# Altium schematic sheet Main Power Input.SchDoc
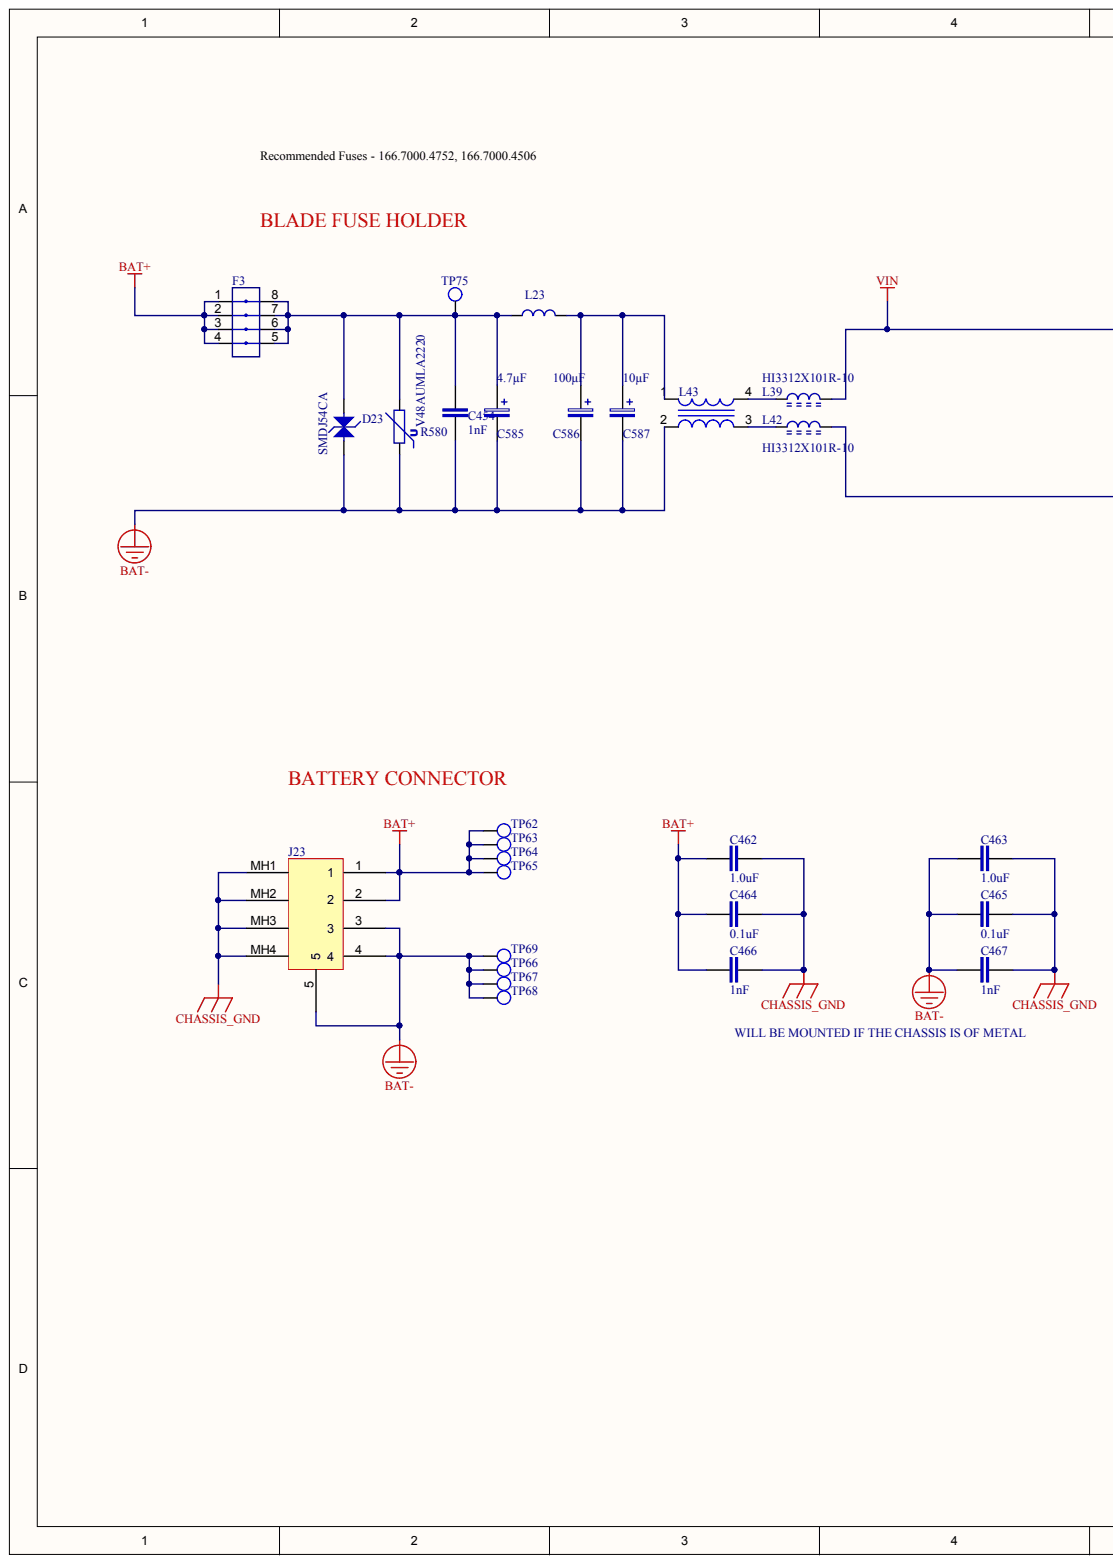
[diagram p0: Main Power Input.SchDoc, left]
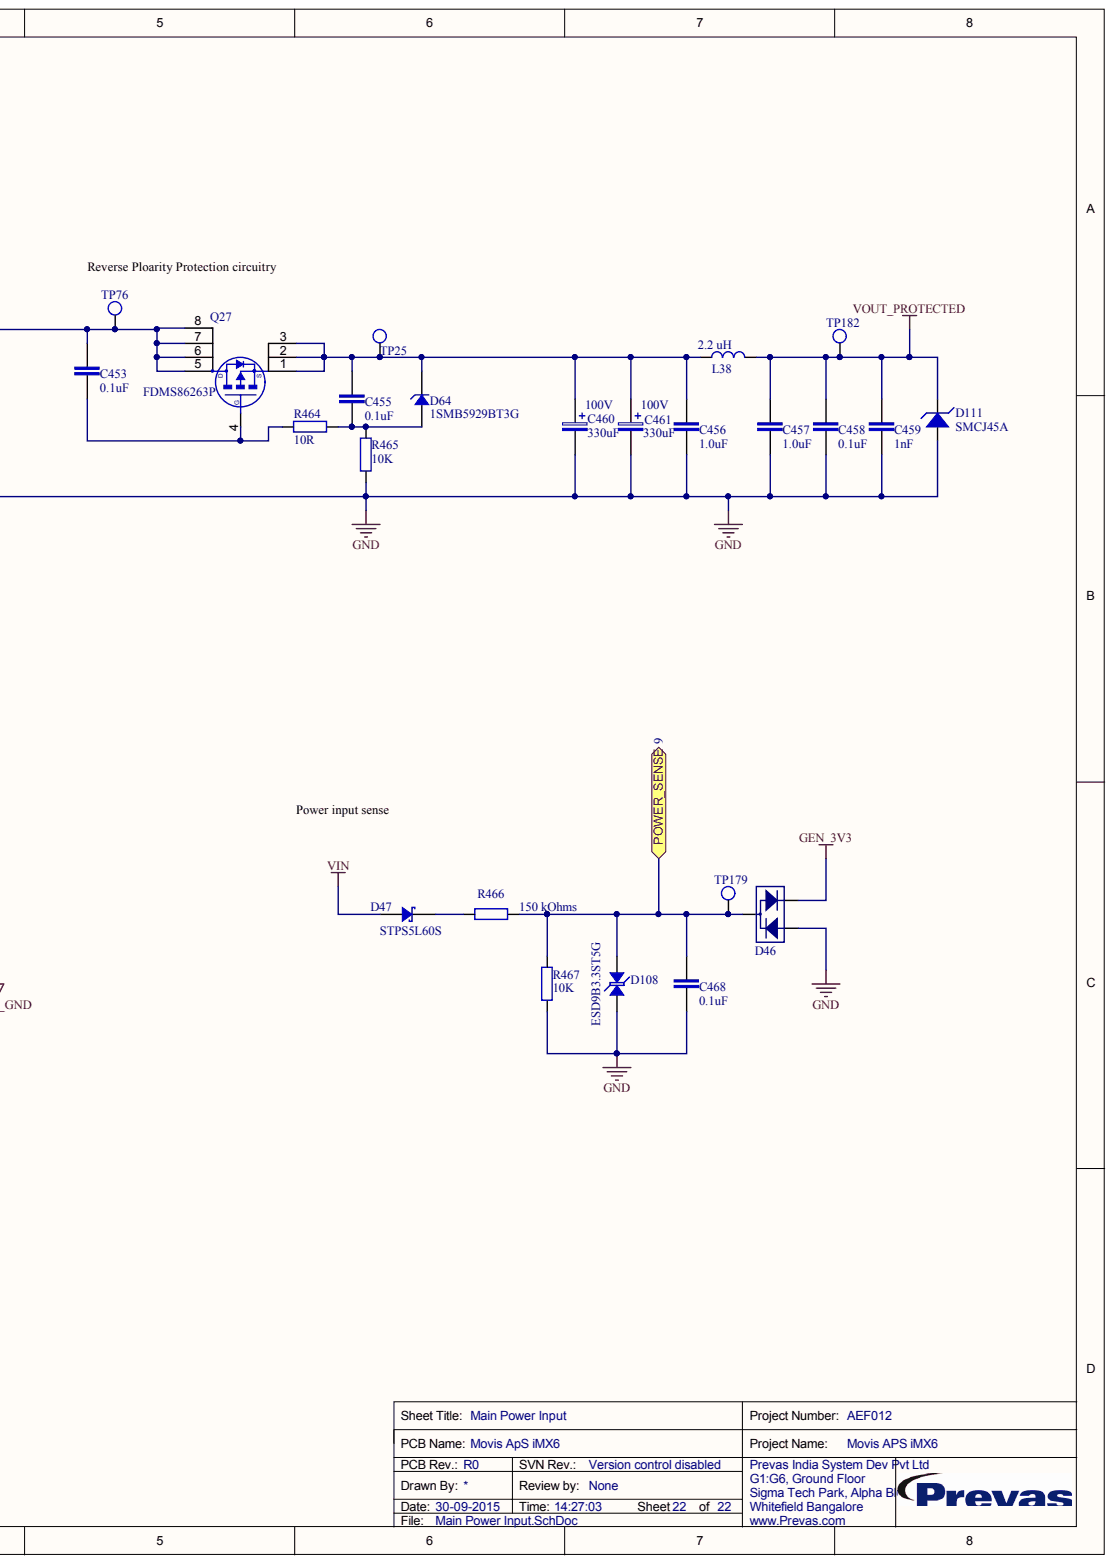
[diagram p1: Main Power Input.SchDoc, right]

## Parts
C453  0.1uF  [CAPC2012X145N]
C454  1nF  [CAPC1608X87N]
C455  0.1uF  [CAPC2012X145N]
C456  1.0uF  elec_voltage_rating: 100V  [CAPC2012X145N]
C457  1.0uF  [CAPC2012X145N]
C458  0.1uF  [CAPC2012X145N]
C459  1nF  [CAPC1608X87N]
C460  330uF  elec_voltage_rating: 100V  [CAPAE1900X1650N]
C461  330uF  elec_voltage_rating: 100V  [CAPAE1900X1650N]
C462  1.0uF  [CAPC2012X145N]
C463  1.0uF  [CAPC2012X145N]
C464  0.1uF  [CAPC2012X145N]
C465  0.1uF  [CAPC2012X145N]
C466  1nF  [CAPC1608X87N]
C467  1nF  [CAPC1608X87N]
C468  0.1uF  [CAPC2012X145N]
C585  4.7µF  [CAPAE8984X103N]
C586  100µF  [CAPAE210191X220N]
C587  10µF  [CAPAE109104X103N]
D23  SMDJ54CA  [DIOM7959X262N]
D46  BAV99  [SOT95P240X110-3N]  (value not drawn)
D47  STPS5L60S  [DIOM7959X265N]
D64  1SMB5929BT3G  [DIOM5436X241N]
D108  ESD9B3.3ST5G  [SODFL1006X43N]
D111  SMCJ45A  [DIOM7959X262N]
F3  178.6165.0001  [FUSE_HOLDER]  (value not drawn)
J23  99-3732-202-04_sch  [CON4RA_M12D]  (value not drawn)
L23  IND  [INDP6872X30N]  (value not drawn)
L38  2.2 uH  [INDC7875X50N]
L39  HI3312X101R-10  [INDC8634X25N]
L42  HI3312X101R-10  [INDC8634X25N]
L43  CM2545X171R-10  [IND_254P1410X1016-4N]  (value not drawn)
Q27  FDMS86263P  [SON127P608X500X110-8N]
R464  10R  [RESC1608X55N]
R465  10K  [RESC1608X55N]
R466  150 kOhms  [RESC3216X60N]
R467  10K  [RESC1608X55N]
R580  elec_value: V48AUMLA2220  [RESC5750X300N]  (hidden comment: RES_VDR)
TP25  Testpoint  [TP_SMD]  (value not drawn)  (no BOM)
TP62  Testpoint  [TP_SMD]  (value not drawn)  (no BOM)
TP63  Testpoint  [TP_SMD]  (value not drawn)  (no BOM)
TP64  Testpoint  [TP_SMD]  (value not drawn)  (no BOM)
TP65  Testpoint  [TP_SMD]  (value not drawn)  (no BOM)
TP66  Testpoint  [TP_SMD]  (value not drawn)  (no BOM)
TP67  Testpoint  [TP_SMD]  (value not drawn)  (no BOM)
TP68  Testpoint  [TP_SMD]  (value not drawn)  (no BOM)
TP69  Testpoint  [TP_SMD]  (value not drawn)  (no BOM)
TP75  Testpoint  [TP_SMD]  (value not drawn)  (no BOM)
TP76  Testpoint  [TP_SMD]  (value not drawn)  (no BOM)
TP179  Testpoint  [TP_SMD]  (value not drawn)  (no BOM)
TP182  Testpoint  [TP_SMD]  (value not drawn)  (no BOM)

## Ports
POWER_SENSE

## Nets
BAT+: C462.2 C464.2 C466.2 F3.1 F3.2 F3.3 F3.4 J23.1 J23.2 TP62.1 TP63.1 TP64.1 TP65.1
BAT-: C454.1 C463.2 C465.2 C467.2 C585.2 C586.2 C587.2 D23.A J23.3 J23.4 J23.5 L43.2 R580.1 TP66.1 TP67.1 TP68.1 TP69.1
CHASSIS_GND: C462.1 C463.1 C464.1 C465.1 C466.1 C467.1 J23.MH1 J23.MH2 J23.MH3 J23.MH4
GEN_3V3: D46.2
GND: C456.1 C457.1 C458.1 C459.1 C460.2 C461.2 C468.1 D46.1 D108.1 D111.A L42.2 R465.2 R467.1
VIN: C453.2 D47.A L39.2 Q27.5 Q27.6 Q27.7 Q27.8 TP76.1
VOUT_PROTECTED: C457.2 C458.2 C459.2 D111.C L38.2 TP182.1
POWER_SENSE: C468.2 D46.3 D108.2 R466.2 R467.2 TP179.1 ; port POWER_SENSE
NetC453_1: C453.1 Q27.4(G) R464.2
NetC454_2: C454.2 C585.1 D23.C F3.5 F3.6 F3.7 F3.8 L23.1 R580.2 TP75.1
NetC455_1: C455.1 D64.A R464.1 R465.1
NetC455_2: C455.2 C456.2 C460.1 C461.1 D64.C L38.1 Q27.1 Q27.2 Q27.3 TP25.1
NetC586_1: C586.1 C587.1 L23.2 L43.1
NetD47_C: D47.C R466.1
NetL39_1: L39.1 L43.4
NetL42_1: L42.1 L43.3

## Library data of the parts
C453 C455 C458 C464 C465 C468 = INNISI-99: CAP CER 0.1UF 100V 10% X7R 0805; ref_part_type: /capacitor/non_polarized/ceramic/; source_manufacturer_1: TDK Corporation; source_manufacturer_1_pn: C2012X7R2A104K125AA; cae_altium_pcb_status: Prototype; Database Table Name: altium_default; db_rev: 7645; elec_temp_range: -55°C ~ 125°C; phy_height: 1.45mm; phy_mounting: SMD; phy_packaging_type: 0805; ref_datasheet: INNISI-87; ref_keyword: Ceramic Capacitor; cae_altium_sch_status: Prototype; Component Kind: Standard; ComponentLink1Description: Datasheet; ComponentLink1URL: https://cop201.prevas.dk/viewvc/datasheet/INNISI-87.pdf?root=hw_components&view=co; db_created: 2015-08-03 11:06:47.0; db_last_updated: 03-08-2015 11:06:49; db_local_id: INNISI-99; db_status: Prototype; elec_tolerance: 10%; elec_value: 0.1uF; elec_voltage_rating: 100V; phy_material: X7R; Library Name: altium_mysql.dblib
C454 C459 C466 C467 = INNISI-101: Ceramic capacitor C0G 0603; ref_part_type: /capacitor/non_polarized/ceramic/; source_manufacturer_1: KEMET; source_manufacturer_1_pn: C0603C102J2GACAUTO; cae_altium_pcb_status: Prototype; Database Table Name: altium_default; db_rev: 7662; elec_temp_range: -55 to 125; elec_tolerance: 5%; elec_voltage_rating: 200V; phy_height: 0.87mm; phy_material: NPO; phy_mounting: SMD; phy_packaging_type: 0603; ref_datasheet: INNISI-88; ref_keyword: Multilayer chip capacitor; cae_altium_sch_status: Prototype; Component Kind: Standard; ComponentLink1Description: Datasheet; ComponentLink1URL: https://cop201.prevas.dk/viewvc/datasheet/INNISI-88.pdf?root=hw_components&view=co; db_created: 2015-08-04 09:54:42.0; db_last_updated: 04-08-2015 09:54:43; db_local_id: INNISI-101; db_status: Prototype; elec_value: 1nF; Library Name: altium_mysql.dblib
C456 C457 C462 C463 = INNISI-100: CAP CER 1UF 100V 10% X7S 0805; ref_part_type: /capacitor/non_polarized/ceramic/; source_manufacturer_1: TDK Corporation; source_manufacturer_1_pn: C2012X7S2A105K125AB; cae_altium_pcb_status: Prototype; Database Table Name: altium_default; db_rev: 7646; elec_temp_range: -55°C ~ 125°C; phy_height: 1.45mm; phy_mounting: SMD; phy_packaging_type: 0805; ref_datasheet: INNISI-87; ref_keyword: Ceramic Capacitor; cae_altium_sch_status: Prototype; Component Kind: Standard; ComponentLink1Description: Datasheet; ComponentLink1URL: https://cop201.prevas.dk/viewvc/datasheet/INNISI-87.pdf?root=hw_components&view=co; db_created: 2015-08-03 11:08:52.0; db_last_updated: 03-08-2015 11:08:54; db_local_id: INNISI-100; db_status: Prototype; elec_tolerance: 10%; elec_value: 1.0uF; phy_material: X7S; Library Name: altium_mysql.dblib
  C457 C462 C463: elec_voltage_rating: 100V
C460 C461 = INRAAN-91: Aluminum electrolytic capacitor; ref_part_type: /capacitor/polarized/al/; source_manufacturer_1: Panasonic; source_manufacturer_1_pn: EEV-FK2A331M; cae_altium_pcb_status: Prototype; Database Table Name: altium_default; db_rev: 6700; elec_frequency_rating: 120Hz; elec_temp_range: -55 C to 105 C; elec_tolerance: 20 %; phy_height: 17mm; phy_mounting: SMD; ref_datasheet: INRAAN-93; cae_altium_sch_status: Prototype; Component Kind: Standard; ComponentLink1Description: Datasheet; ComponentLink1URL: https://cop201.prevas.dk/viewvc/datasheet/INRAAN-93.pdf?root=hw_components&view=co; db_created: 2014-02-10 09:01:09.0; db_last_updated: 9/1/2014 4:27:05 AM; db_local_id: INRAAN-91; db_status: Prototype; elec_value: 330uF; ref_keyword: Aluminum electrolytic capacitor; Library Name: altium_mysql.dblib
C585 = INNISI-71: CAP ALUM 4.7UF 20% 200V SMD; ref_part_type: /capacitor/polarized/al/; source_manufacturer_1: Nichicon; source_manufacturer_1_pn: UUB2D4R7MNL1GS; cae_altium_pcb_status: Prototype; Database Table Name: altium_default; db_rev: 7509; elec_temp_range: -40°C ~ 125°C; phy_height: 10.50mm; ref_datasheet: INNISI-69; ref_keyword: Aluminum Capacitors; cae_altium_sch_status: Prototype; Component Kind: Standard; ComponentLink1Description: Datasheet; ComponentLink1URL: https://cop201.prevas.dk/viewvc/datasheet/INNISI-69.pdf?root=hw_components&view=co; db_created: 2015-07-08 05:07:25.0; db_last_updated: 08-07-2015 05:07:27; db_local_id: INNISI-71; db_status: Prototype; elec_tolerance: ±20%; elec_value: 4.7µF; elec_voltage_rating: 200V; phy_mounting: SMD; Library Name: altium_mysql.dblib
C586 = INNISI-72: CAP ALUM 100UF 20% 200V SMD; ref_part_type: /capacitor/polarized/al/; source_manufacturer_1: Panasonic Electronic Components; source_manufacturer_1_pn: EEV-EB2D101M; cae_altium_pcb_status: Prototype; Database Table Name: altium_default; db_rev: 7510; elec_temp_range: -25°C ~ 105°C; phy_height: 21.50mm; ref_datasheet: INNISI-70; ref_keyword: Aluminum Capacitors; cae_altium_sch_status: Prototype; Component Kind: Standard; ComponentLink1Description: Datasheet; ComponentLink1URL: https://cop201.prevas.dk/viewvc/datasheet/INNISI-70.pdf?root=hw_components&view=co; db_created: 2015-07-08 05:14:06.0; db_last_updated: 08-07-2015 05:14:08; db_local_id: INNISI-72; db_status: Prototype; elec_tolerance: ±20%; elec_value: 100µF; elec_voltage_rating: 200V; phy_mounting: SMD; Library Name: altium_mysql.dblib
C587 = INNISI-70: CAP ALUM 10UF 20% 200V SMD; ref_part_type: /capacitor/polarized/al/; source_manufacturer_1: Nichicon; source_manufacturer_1_pn: UUB2D100MNL1GS; cae_altium_pcb_status: Prototype; Database Table Name: altium_default; db_rev: 7508; elec_temp_range: -40°C ~ 125°C; ref_datasheet: INNISI-69; ref_keyword: Alumininum Capacitors; cae_altium_sch_status: Prototype; Component Kind: Standard; ComponentLink1Description: Datasheet; ComponentLink1URL: https://cop201.prevas.dk/viewvc/datasheet/INNISI-69.pdf?root=hw_components&view=co; db_created: 2015-07-08 05:00:18.0; db_last_updated: 08-07-2015 05:00:20; db_local_id: INNISI-70; db_status: Prototype; elec_tolerance: ±20%; elec_value: 10µF; elec_voltage_rating: 200V; phy_mounting: SMD; Library Name: altium_mysql.dblib
D23 = (no symbol name): TVS Diodes - Transient Voltage Suppressors TVS Diode SMC Suf MT; ref_part_type: /diode/transient/; source_manufacturer_1: Littelfuse; cae_altium_pcb_status: Prototype; db_local_id: INRAAN-202; db_rev: 6840; elec_temp_range: -65C to 150C; elec_voltage_rating: 54V; phy_height: 2.62mm; phy_mounting: SMD; phy_packaging_type: DO-214AB; ref_datasheet: INRAAN-187; cae_altium_sch_status: Prototype; Component Kind: Standard; ComponentLink1Description: Datasheet; ComponentLink1URL: https://cop201.prevas.dk/viewvc/datasheet/INRAAN-187.pdf?root=hw_components&view=co; db_created: 2014-09-12 06:34:12.0; db_last_updated: 9/12/2014 6:34:14 AM; db_status: Prototype; elec_value: SMDJ54CA; ref_keyword: TVS Diodes - Transient Voltage Suppressors; source_manufacturer_1_pn: SMDJ54CA; Library Name: Movis-main-card.SCHLIB
D46 = JEPE-21: Diode Silicon Low Leakage SOT-23; ref_part_type: /diode/normal/; source_manufacturer_1: Infineon; cae_altium_pcb_status: Prototype; Database Table Name: altium_default; db_rev: 2162; elec_current_rating: 200mA; elec_power_rating: 330mW; elec_temp_range: -65 to +150; elec_value: BAV199; elec_voltage_rating: 80V; phy_height: 1.0mm; phy_mounting: SMD; ref_datasheet: JEPE-15; ref_keyword: Diode SMD Series Pair Configuration; cae_altium_sch_status: Prototype; Component Kind: Standard; ComponentLink1Description: Datasheet; ComponentLink1URL: https://cop201.prevas.dk/viewvc/datasheet/JEPE-15.pdf?root=hw_components&view=co; db_created: 2008-05-28 13:53:32; db_last_updated: 3/1/2010 1:26:01 PM; db_local_id: JEPE-21; db_status: Prototype; phy_packaging_type: SOT-23; source_manufacturer_1_pn: BAV199; Library Name: altium_mysql.dblib
D47 = NIMA-251: Diode Power Rectifier Case DO-214AB; ref_part_type: /diode/schottky/; source_manufacturer_1: STMicroelectronics; cae_altium_pcb_status: Prototype; Database Table Name: altium_default; db_rev: 4795; elec_current_rating: 5A; elec_temp_range: -65 to 150; elec_voltage_rating: 60V; phy_height: 2.65mm; phy_material: Epoxy; phy_mounting: SMD; phy_packaging_type: SMC; ref_datasheet: NIMA-182; ref_keyword: Schottky Diode SMD; cae_altium_sch_status: Prototype; Component Kind: Standard; ComponentLink1Description: Datasheet; ComponentLink1URL: https://cop201.prevas.dk/viewvc/datasheet/NIMA-182.pdf?root=hw_components&view=co; db_created: 2012-06-27 23:43:13.0; db_last_updated: 6/27/2012 11:43:13 PM; db_local_id: NIMA-251; db_status: Prototype; elec_value: STPS5L60S; source_manufacturer_1_pn: STPS5L60S; Library Name: altium_mysql.dblib
D64 = CHPE-286: Zener Diode 15V 3W; ref_part_type: /diode/zener/; source_manufacturer_1: ON Semiconductor; cae_altium_pcb_status: Prototype; Database Table Name: altium_default; db_rev: 4492; elec_temp_range: -65 to +150; phy_height: 2.41mm; phy_material: Silicon; phy_mounting: SMD; phy_packaging_type: SMB; ref_datasheet: GEPW-102; ref_keyword: Zener Diode SMD; cae_altium_sch_status: Prototype; Component Kind: Standard; ComponentLink1Description: Datasheet; ComponentLink1URL: https://cop201.prevas.dk/viewvc/datasheet/GEPW-102.pdf?root=hw_components&view=co; db_created: 2012-04-02 03:10:43.0; db_last_updated: 4/2/2012 3:10:44 AM; db_local_id: CHPE-286; db_status: Prototype; elec_power_rating: 3W; elec_value: 1SMB5929BT3G; elec_voltage_rating: 15V; source_manufacturer_1_pn: 1SMB5929BT3G; Library Name: altium_mysql.dblib
D108 = INRAAN-22: Micro?Packaged Diodes for ESD Protection; ref_part_type: /diode/schottky/; source_manufacturer_1: ON SEMICONDUCTOR; cae_altium_pcb_status: Prototype; Database Table Name: altium_default; db_rev: 6515; elec_current_rating: 1A; elec_temp_range: -55 TO 150; elec_voltage_rating: 3.3 TO 5V; phy_height: 0.43; phy_mounting: SMD; phy_packaging_type: SOD-923; ref_datasheet: INRAAN-24; cae_altium_sch_status: Prototype; Component Kind: Standard; ComponentLink1Description: Datasheet; ComponentLink1URL: https://cop201.prevas.dk/viewvc/datasheet/INRAAN-24.pdf?root=hw_components&view=co; db_created: 2013-11-18 07:59:40.0; db_last_updated: 4/3/2014 6:43:30 AM; db_local_id: INRAAN-22; db_status: Prototype; elec_value: ESD9B3.3ST5G; ref_keyword: SOD-923; source_manufacturer_1_pn: ESD9B3.3ST5G; Library Name: altium_mysql.dblib
D111 = (no symbol name): TVS Diodes - Transient Voltage Suppressors 45Vr 1500W 20.6A 5% UniDirectional; ref_part_type: /diode/transient/; source_manufacturer_1: Littelfuse; cae_altium_pcb_status: Prototype; db_local_id: INRAAN-201; db_rev: 6839; elec_temp_range: -65C to 150C; elec_voltage_rating: 45V; phy_height: 2.62mm; phy_mounting: SMD; phy_packaging_type: DO-214AB; ref_datasheet: INRAAN-186; cae_altium_sch_status: Prototype; Component Kind: Standard; ComponentLink1Description: Datasheet; ComponentLink1URL: https://cop201.prevas.dk/viewvc/datasheet/INRAAN-186.pdf?root=hw_components&view=co; db_created: 2014-09-12 06:24:26.0; db_last_updated: 9/12/2014 6:30:48 AM; db_status: Prototype; elec_value: SMCJ45A; ref_keyword: TVS Diodes - Transient Voltage Suppressors; source_manufacturer_1_pn: SMCJ45A; Library Name: Movis-main-card.SCHLIB
F3 = INNISI-65: Fuse Holder FUSEHOLDER FOR FLR; ref_part_type: /elmech/fuse/; source_manufacturer_1: Littelfuse; cae_altium_pcb_status: Prototype; Database Table Name: altium_default; db_rev: 7467; elec_voltage_rating: 80 V; phy_mounting: Leaded; ref_datasheet: INNISI-64; cae_altium_sch_status: Prototype; Component Kind: Standard; ComponentLink1Description: Datasheet; ComponentLink1URL: https://cop201.prevas.dk/viewvc/datasheet/INNISI-64.pdf?root=hw_components&view=co; db_created: 2015-06-18 09:52:46.0; db_last_updated: 18-06-2015 09:52:48; db_local_id: INNISI-65; db_status: Prototype; ref_keyword: Fuse Holder; source_manufacturer_1_pn: 178.6165.0001; Library Name: altium_mysql.dblib
J23 = INNISI-48: Female receptacle, 90°, rear-mounted, PCB solder; ref_part_type: /connector/4 pins/; source_manufacturer_1: Binder; cae_altium_pcb_status: Prototype; Database Table Name: altium_default; db_rev: 7471; elec_temp_range: -40 C- +85 C; elec_value: 99 3732 203 04; elec_voltage_rating: 250 V; phy_mounting: Leaded; ref_datasheet: INNISI-60; ref_keyword: Female panel mount 4 pin connector; cae_altium_sch_status: Prototype; Component Kind: Standard; ComponentLink1Description: Datasheet; ComponentLink1URL: https://cop201.prevas.dk/viewvc/datasheet/INNISI-60.pdf?root=hw_components&view=co; db_created: 2015-06-08 10:04:26.0; db_last_updated: 19-06-2015 04:02:02; db_local_id: INNISI-48; db_status: Prototype; source_manufacturer_1_pn: 99 3732 203 04; Library Name: altium_mysql.dblib
L23 = INNISI-69: Fixed Inductors 1.00uH 20%; ref_part_type: /inductor/; source_manufacturer_1: TT Electronics; source_manufacturer_1_pn: HA72E-061R0LFTR13; cae_altium_pcb_status: Prototype; Database Table Name: altium_default; db_rev: 7507; elec_current_rating: 10.3A; elec_frequency_rating: 100KHz; elec_temp_range: - 40 C to + 155 C; elec_value: 1.uH; phy_height: 3 mm; phy_mounting: SMD; ref_datasheet: INNISI-68; ref_keyword: Fixed Inductor 1.00uH; cae_altium_sch_status: Prototype; Component Kind: Standard; ComponentLink1Description: Datasheet; ComponentLink1URL: https://cop201.prevas.dk/viewvc/datasheet/INNISI-68.pdf?root=hw_components&view=co; db_created: 2015-07-08 04:41:35.0; db_last_updated: 08-07-2015 04:41:37; db_local_id: INNISI-69; db_status: Prototype; elec_tolerance: 20%; Library Name: altium_mysql.dblib
L38 = INNISI-25: Fixed Inductors 2.2uH 14A; ref_part_type: /inductor/; source_manufacturer_1: Coiltronics; source_manufacturer_1_pn: HCP0805-2R2-R; cae_altium_pcb_status: Prototype; Database Table Name: altium_default; db_rev: 7358; elec_frequency_rating: 2MHz; elec_temp_range: - 40 C to + 125 C; elec_tolerance: 25 %; phy_height: 5 mm; phy_mounting: SMD; cae_altium_sch_status: Prototype; Component Kind: Standard; ComponentLink1Description: Datasheet; ComponentLink1URL: https://cop201.prevas.dk/viewvc/datasheet/INNISI-25.pdf?root=hw_components&view=co; db_created: 2015-06-02 09:23:47.0; db_last_updated: 02-06-2015 09:23:48; db_local_id: INNISI-25; db_status: Prototype; elec_current_rating: 14 A; elec_value: 2.2 uH; ref_datasheet: INNISI-25; ref_keyword: Fixed Inductors; Library Name: altium_mysql.dblib
L39 L42 = INNISI-26: EMI Filter Beads, Chips & Arrays 100ohms 100MHz 10A Monolithic 3312 SMD; ref_part_type: /inductor/; source_manufacturer_1: Laird Technologies; cae_altium_pcb_status: Prototype; Database Table Name: altium_default; db_rev: 7359; elec_temp_range: - 55 C to + 125 C; phy_height: 2.28mm; phy_packaging_type: 3312 (8531 metric); ref_keyword: EMI Ferrite Chip Beads; cae_altium_sch_status: Prototype; Component Kind: Standard; ComponentLink1Description: Datasheet; ComponentLink1URL: https://cop201.prevas.dk/viewvc/datasheet/INNISI-26.pdf?root=hw_components&view=co; db_created: 2015-06-02 09:35:27.0; db_last_updated: 02-06-2015 09:35:28; db_local_id: INNISI-26; db_status: Prototype; elec_current_rating: 10A; elec_value: HI3312X101R-10; phy_mounting: SMD; ref_datasheet: INNISI-26; source_manufacturer_1_pn: HI3312X101R-10; Library Name: altium_mysql.dblib
L43 = INNISI-51: CHOKE COMMON MODE 170 OHMS SMD; ref_part_type: /filter/choke/; source_manufacturer_1: Laird Technologies; cae_altium_pcb_status: Prototype; Database Table Name: altium_default; db_rev: 7408; elec_current_rating: 10A; elec_temp_range: - 40 C to + 125 C; phy_height: 9.32mm; ref_datasheet: INNISI-49; ref_keyword: Ferrite Chip Beads, Common Mode; cae_altium_sch_status: Prototype; Component Kind: Standard; ComponentLink1Description: Datasheet; ComponentLink1URL: https://cop201.prevas.dk/viewvc/datasheet/INNISI-49.pdf?root=hw_components&view=co; db_created: 2015-06-09 03:50:21.0; db_last_updated: 09-06-2015 03:50:23; db_local_id: INNISI-51; db_status: Prototype; elec_value: CM2545X171R-10; phy_mounting: SMD; source_manufacturer_1_pn: CM2545X171R-10; Library Name: altium_mysql.dblib
Q27 = INRAAN-213: MOSFET PT5 150/25V Pch Pwr Trench MOSFET; ref_part_type: /transistor/mosfet/p_channel/; source_manufacturer_1: Fairchild Semiconductor; cae_altium_pcb_status: Prototype; Database Table Name: altium_default; db_rev: 6859; elec_power_rating: 104W; elec_temp_range: -55C to 150C; phy_height: 1.1mm; phy_mounting: SMD; phy_packaging_type: SON-8; ref_datasheet: INRAAN-198; ref_keyword: MOSFET P-Channel; cae_altium_sch_status: Prototype; Component Kind: Standard; ComponentLink1Description: Datasheet; ComponentLink1URL: https://cop201.prevas.dk/viewvc/datasheet/INRAAN-198.pdf?root=hw_components&view=co; db_created: 2014-09-16 09:28:08.0; db_last_updated: 9/16/2014 9:33:26 AM; db_local_id: INRAAN-213; db_status: Prototype; elec_value: FDMS86263P; source_manufacturer_1_pn: FDMS86263P; Library Name: altium_mysql.dblib
R464 = INVIHA-55: RES SMD 10 OHM 1% 1/4W 0603; ref_part_type: /resistor/fixed/; source_manufacturer_1: Vishay Dale; source_manufacturer_1_pn: CRCW060310R0FKEAHP; cae_altium_pcb_status: Prototype; Database Table Name: altium_default; db_rev: 7688; elec_power_rating: 0.25W; elec_temp_range: -55 to +155° C; phy_height: 0.55mm; phy_packaging_type: 0603; ref_datasheet: INVIHA-13; ref_keyword: Chip Resistor; cae_altium_sch_status: Prototype; Component Kind: Standard; ComponentLink1Description: Datasheet; ComponentLink1URL: https://cop201.prevas.dk/viewvc/datasheet/INVIHA-13.pdf?root=hw_components&view=co; db_created: 2015-08-06 06:12:47.0; db_last_updated: 06-08-2015 06:12:49; db_local_id: INVIHA-55; db_status: Prototype; elec_tolerance: 1%; elec_value: 10R; phy_mounting: SMD; Library Name: altium_mysql.dblib
R465 R467 = INVIHA-3: RES SMD 10K OHM 1% 1/10W 0603; ref_part_type: /resistor/fixed/; source_manufacturer_1: Yageo; source_manufacturer_1_pn: RC0603FR-0710KL; cae_altium_pcb_status: Prototype; Database Table Name: altium_default; db_rev: 7575; elec_power_rating: 0.1W; elec_temp_range: -55 to +155° C; phy_height: 0.55mm; phy_packaging_type: 0603; ref_datasheet: INVIHA-2; ref_keyword: Chip Resistor; cae_altium_sch_status: Prototype; Component Kind: Standard; ComponentLink1Description: Datasheet; ComponentLink1URL: https://cop201.prevas.dk/viewvc/datasheet/INVIHA-2.pdf?root=hw_components&view=co; db_created: 2015-08-03 05:39:03.0; db_last_updated: 03-08-2015 5:39:05 AM; db_local_id: INVIHA-3; db_status: Prototype; elec_tolerance: 1%; elec_value: 10K; phy_mounting: SMD; Library Name: altium_mysql.dblib
R466 = INRAAN-118: Thick Film Resistor; ref_part_type: /resistor/fixed/; source_manufacturer_1: Vishay / Dale; source_manufacturer_1_pn: CRCW1206150KJNEA; cae_altium_pcb_status: Prototype; Database Table Name: altium_default; db_rev: 6423; elec_temp_range: -55 C to 155 C; elec_tolerance: 5 %; elec_voltage_rating: 200V; phy_height: 0.6mm; phy_mounting: SMD; phy_packaging_type: 1206; ref_datasheet: INRAAN-120; cae_altium_sch_status: Prototype; Component Kind: Standard; ComponentLink1Description: Datasheet; ComponentLink1URL: https://cop201.prevas.dk/viewvc/datasheet/INRAAN-120.pdf?root=hw_components&view=co; db_created: 2014-03-13 13:05:59.0; db_last_updated: 3/13/2014 1:06:01 PM; db_local_id: INRAAN-118; db_status: Prototype; elec_value: 150 kOhms; phy_material: Thick Film; ref_keyword: Thick Film Resistor; Library Name: altium_mysql.dblib
R580 = INRAAN-212: Surface Mount Multilayer Varistors (MLVs) > AUML Series; ref_part_type: /resistor/vdr/varistor/; source_manufacturer_1: LittelFuse; cae_altium_pcb_status: Prototype; Database Table Name: altium_default; db_rev: 6857; elec_temp_range: -55C to 155C; elec_voltage_rating: 48V DC; phy_height: 3mm; phy_mounting: SMD; phy_packaging_type: 2220; ref_datasheet: INRAAN-197; cae_altium_sch_status: Prototype; Component Kind: Standard; ComponentLink1Description: Datasheet; ComponentLink1URL: https://cop201.prevas.dk/viewvc/datasheet/INRAAN-197.pdf?root=hw_components&view=co; db_created: 2014-09-15 09:05:36.0; db_last_updated: 9/15/2014 9:05:38 AM; db_local_id: INRAAN-212; db_status: Prototype; ref_keyword: Varistor; source_manufacturer_1_pn: V48AUMLA2220; Library Name: altium_mysql.dblib
TP25 TP62-TP69 TP75 TP76 TP179 TP182 = JEPE-114: Test Point SMD; ref_part_type: /pcb_item/; cae_altium_pcb_status: Prototype; Database Table Name: altium_default; db_rev: 3281; cae_altium_sch_status: Prototype; ComponentLink1Description: Datasheet; db_created: 2008-08-18 11:39:42; db_local_id: JEPE-114; db_status: Prototype; elec_value: Test Point; phy_mounting: SMD; ref_keyword: Test  Point; Library Name: altium_mysql.dblib
  TP25: db_last_updated: 23-03-2011 14:14:06
  TP62-TP69 TP75 TP76 TP179 TP182: db_last_updated: 3/23/2011 2:14:06 PM
